# Altium schematic sheet 原理图2.SchDoc(原理图2)
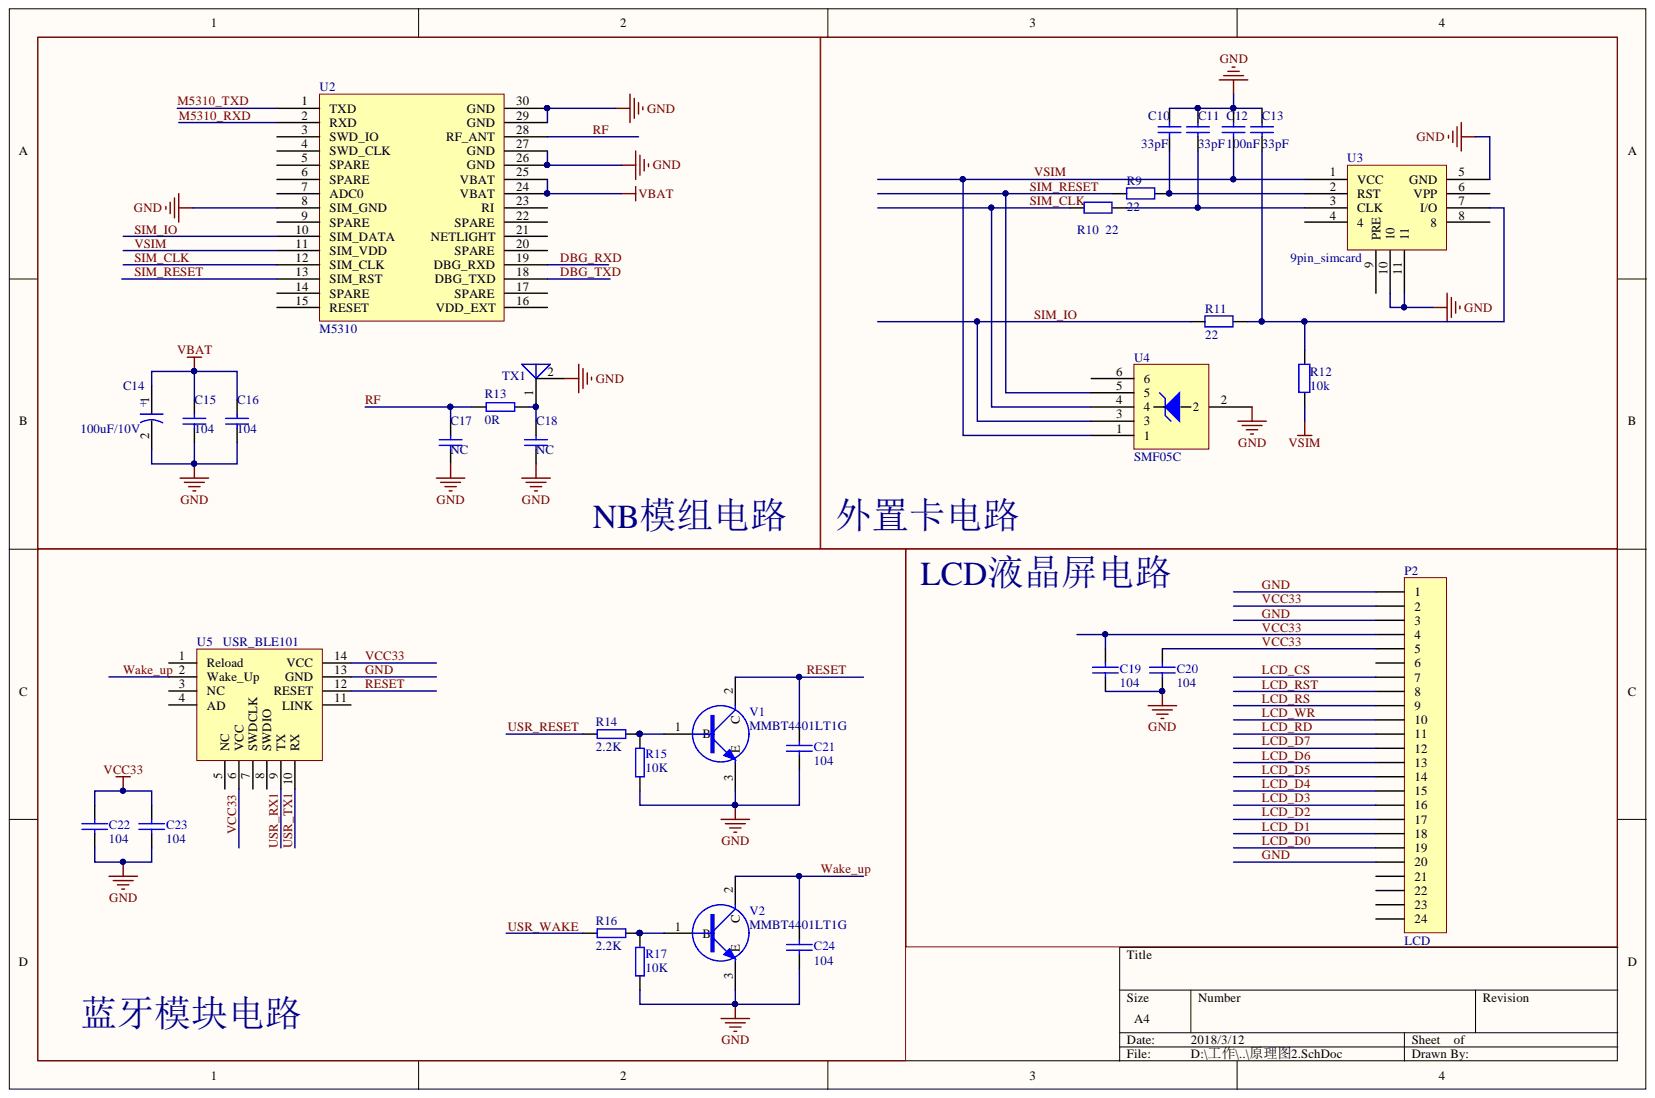
[diagram p0: 原理图2.SchDoc]

## Parts
C10  33pF  [0402]
C11  33pF  [0402]
C12  33pF  value 100nF  [0402]
C13  33pF  [0402]
C14  100uF/10V  [ELECTRO1210]
C15  104  [0402]
C16  104  [0402]
C17  value NC  [0402]  (hidden comment: 104)
C18  value NC  [0402]  (hidden comment: 104)
C19  104  [0402]
C20  104  [0402]
C21  104  [0402]
C22  104  [0402]
C23  104  [0402]
C24  104  [0402]
P2  LCD  [LCD_24P]
R9  value 22  [0402]  (hidden comment: 22R)
R10  value 22  [0402]  (hidden comment: 22R)
R11  value 22  [0402]  (hidden comment: 22R)
R12  10K  [0402]
R13  0R  [0402]
R14  2.2K  [0402]
R15  10K  [0402]
R16  2.2K  [0402]
R17  10K  [0402]
TX1  TX1  [IPEX_ANT]
U2  M5310  [M5310]
U3  9pin_simcard  [simcard_9pin]
U4  SMF05C  [SOT23-LMT84A]
U5  USR_BLE101  [USR_BLE101]
V1  MMBT4401LT1G  [SOT-23]
V2  MMBT4401LT1G  [SOT-23]

## Nets
VBAT: C14.1 C15.1 C16.1 U2.24(VBAT) U2.25(VBAT)
DBG_RXD: U2.19(DBG_RXD)
DBG_TXD: U2.18(DBG_TXD)
GND: C10.1 C11.1 C12.1 C13.1 C14.2 C15.2 C16.2 C17.2 C18.2 C19.1 C20.1 C21.1 C22.1 C23.1 C24.1 P2.1 P2.3 P2.20 R15.2 R17.2 TX1.2 U2.8(SIM_GND) U2.26(GND) U2.27(GND) U2.29(GND) U2.30(GND) U3.5(GND) U3.10 U3.11 U4.2 U5.13(GND) V1.3 V2.3
LCD_CS: P2.7
LCD_D0: P2.19
LCD_D1: P2.18
LCD_D2: P2.17
LCD_D3: P2.16
LCD_D4: P2.15
LCD_D5: P2.14
LCD_D6: P2.13
LCD_D7: P2.12
LCD_RD: P2.11
LCD_RS: P2.9
LCD_RST: P2.8
LCD_WR: P2.10
M5310_RXD: U2.2(RXD)
M5310_TXD: U2.1(TXD)
RESET: C21.2 U5.12(RESET) V1.2
RF: C17.1 R13.2 U2.28(RF_ANT)
SIM_CLK: R10.1 U2.12(SIM_CLK) U4.4
SIM_IO: R11.1 U2.10(SIM_DATA) U4.3
SIM_RESET: R9.1 U2.13(SIM_RST) U4.5
USR_RESET: R14.2
USR_RX1: U5.9(TX)
USR_TX1: U5.10(RX)
USR_WAKE: R16.2
VCC33: C19.2 C20.2 C22.2 C23.2 P2.2 P2.4 P2.5 U5.6(VCC) U5.14(VCC)
VSIM: C12.2 R12.1 U2.11(SIM_VDD) U3.1(VCC) U4.1
WAKE_UP: C24.2 U5.2(Wake_Up) V2.2
NetC10_2: C10.2 R9.2 U3.2(RST)
NetC11_2: C11.2 R10.2 U3.3(CLK)
NetC13_2: C13.2 R11.2 R12.2 U3.7(I/O)
NetC18_1: C18.1 R13.1 TX1.1
NetR14_1: R14.1 R15.1 V1.1
NetR16_1: R16.1 R17.1 V2.1

Not connected: P2 6 21 22 23 24; U2 3(SWD_IO) 4(SWD_CLK) 5(SPARE) 6(SPARE) 7(ADC0) 9(SPARE) 14(SPARE) 15(RESET) 16(VDD_EXT) 17(SPARE) 20(SPARE) 21(NETLIGHT) 22(SPARE) 23(RI); U3 4 6(VPP) 8 9(PRE); U4 6; U5 1(Reload) 3(NC) 4(AD) 5(NC) 7(SWDCLK) 8(SWDIO) 11(LINK)

## Library data of the parts
C10-C13 C15-C18 = 0201: Component Kind: Standard
  C10 C11 C13: Value: 33pF
  C15 C16: Value: 104
C14 = 02050003: 贴片钽电容; Component Kind: Standard; Value: 100uF/10V
C19-C24 = DR: Component Kind: Standard; Library Name: ydlZXSCHTK.SCHLIB
P2 = Header 24: Header, 24-Pin; Component Kind: Standard; LatestRevisionDate: 17-Jul-2002; LatestRevisionNote: Re-released for DXP Platform.; Library Name: Miscellaneous Connectors.IntLib; Publisher: Altium Limited
R9-R12 = 0101: Component Kind: Standard
  R12: Value: 10k
R13-R17 = RES1: 标准电阻; : 片电阻; Component Kind: Standard; Library Name: 电容电阻.SchLib
TX1 = TX2: Component Kind: Standard; Library Name: ydlZXSCHTK.SCHLIB
V1 V2 = NPN: 三极管; : 贴片封装; Component Kind: Standard
U2 = M5310: Component Kind: Standard; Library Name: 参考设计库.SchLib
U3 = 9pin_simcard: Component Kind: Standard; Library Name: 参考设计库.SchLib
U4 = SMF05C: Component Kind: Standard; Library Name: 分立元件.SCHLIB
U5 = USR_BLE101: Component Kind: Standard; Library Name: 参考设计库.SchLib
